# Altium schematic sheet Amplifier.SchDoc
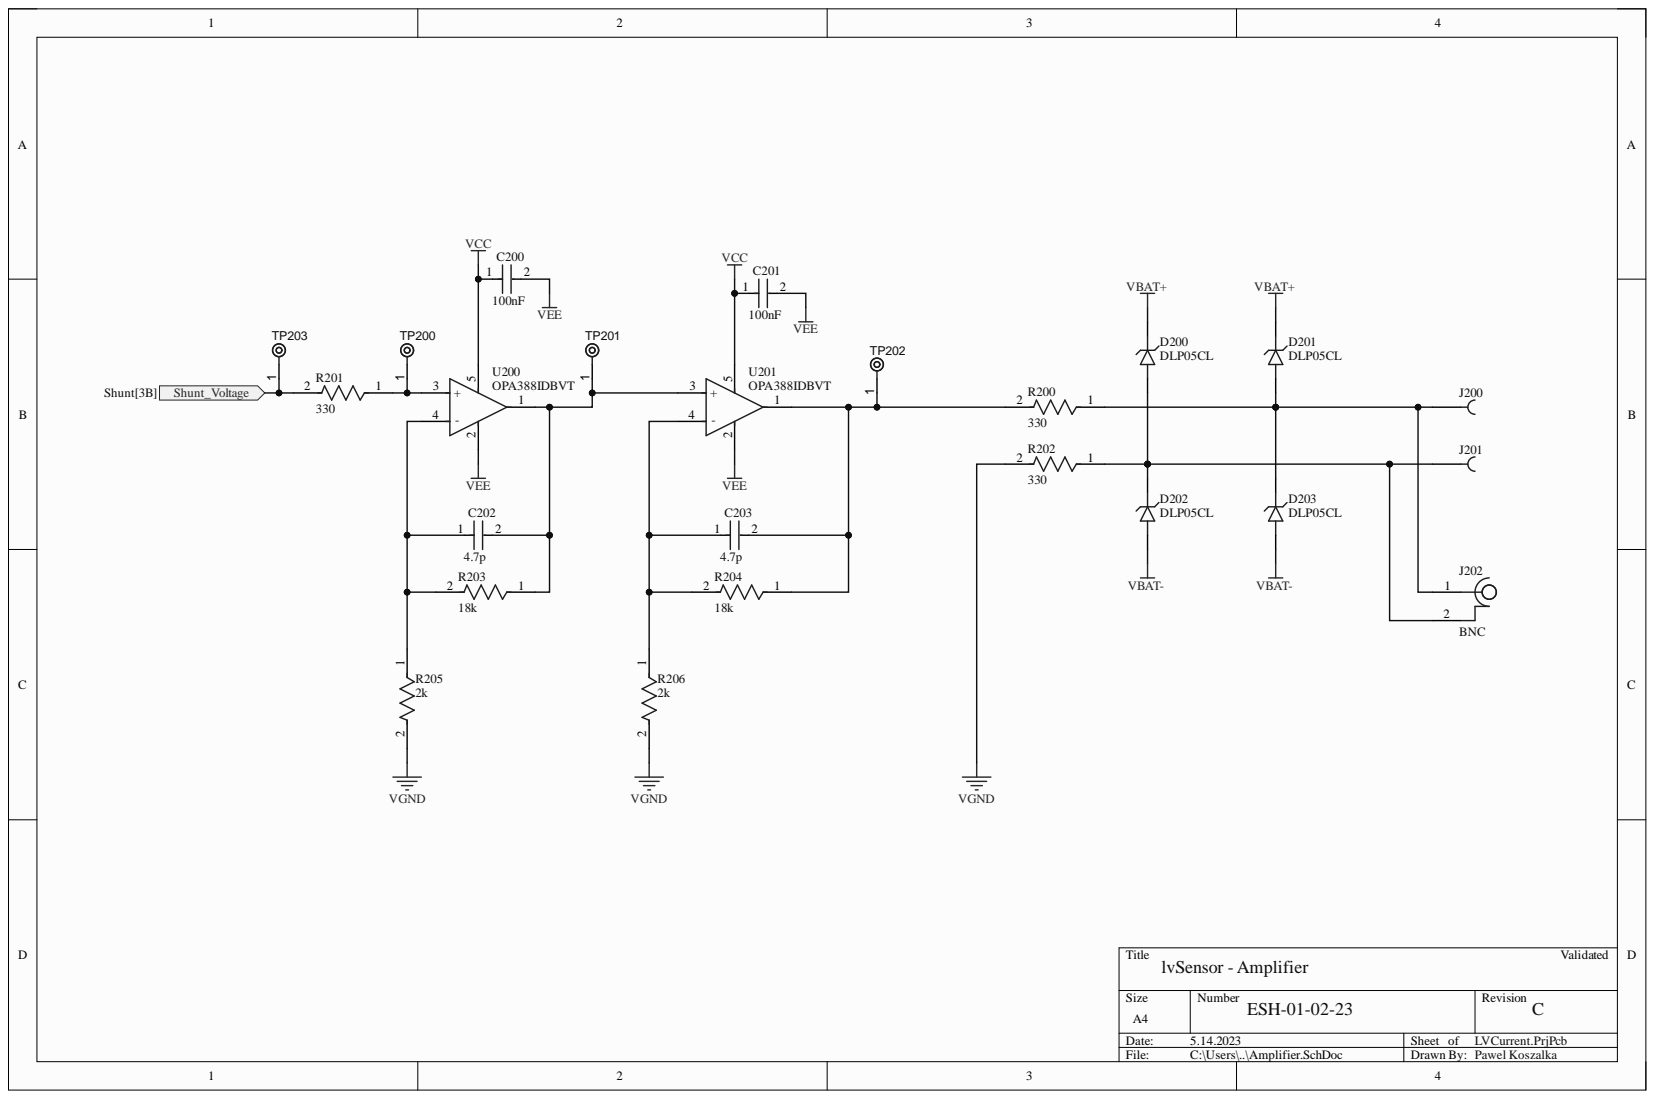
[diagram p0: Amplifier.SchDoc]

## Parts
C200  100nF  [FP-GRM21B-0_15-IPC_C]
C201  100nF  [FP-GRM21B-0_15-IPC_C]
C202  4.7p  [FP-GRM21B-0_15-IPC_C]
C203  4.7p  [FP-GRM21B-0_15-IPC_C]
D200  DLP05CL  [DLP05CL]
D201  DLP05CL  [DLP05CL]
D202  DLP05CL  [DLP05CL]
D203  DLP05CL  [DLP05CL]
J200  BananaConnector_4_Long  [BananaConnector_4mm_long]  (value not drawn)
J201  BananaConnector_4_Long  [BananaConnector_4mm_long]  (value not drawn)
J202  BNC  [BNC_Routed_Hole]
R200  330  [FP-RC1206-MFG]
R201  330  [FP-RC1206-MFG]
R202  330  [FP-RC1206-MFG]
R203  18k  [FP-RC1206-MFG]
R204  18k  [FP-RC1206-MFG]
R205  2k  [FP-RC1206-MFG]
R206  2k  [FP-RC1206-MFG]
TP200  TPAD6R  [TP06R]  (value not drawn)  (no BOM)
TP201  TPAD6R  [TP06R]  (value not drawn)  (no BOM)
TP202  TPAD6R  [TP06R]  (value not drawn)  (no BOM)
TP203  TPAD6R  [TP06R]  (value not drawn)  (no BOM)
U200  OPA388IDBVT  [FP-DBV0005A-MFG]
U201  OPA388IDBVT  [FP-DBV0005A-MFG]

## Ports
Shunt_Voltage

## Nets
VBAT+: D200.1 D201.1
VBAT-: D202.2 D203.2
VCC: C200.1 C201.1 U200.5 U201.5
VEE: C200.2 C201.2 U200.2 U201.2
VGND: R202.2 R205.2 R206.2
Shunt_Voltage: R201.2 TP203.1 ; port Shunt_Voltage
NetC202_1: C202.1 R203.2 R205.1 U200.4(-)
NetC202_2: C202.2 R203.1 TP201.1 U200.1 U201.3(+)
NetC203_1: C203.1 R204.2 R206.1 U201.4(-)
NetC203_2: C203.2 R200.2 R204.1 TP202.1 U201.1
NetD200_2: D200.2 D202.1 J201.1 J202.2 R202.1
NetD201_2: D201.2 D203.1 J200.1 J202.1 R200.1
NetR201_1: R201.1 TP200.1 U200.3(+)

## Library data of the parts
C200 C201 = CMP-2007-03541-2: CC0805KPX7R9104  0805 Capacitor; Capacitance: 10uF; Dielectric: X5R; Material: Ceramic; Tolerance: 10%; Voltage: 25V; Case Code (Imperial): 0805; Case Code (Metric): 2012; Case/Package: 0805; Component Kind: Standard; Depth: 1.25mm; Height: 1.25mm; Lead Free: Lead Free; Length: 2mm; Library Name: Altium Content Vault; Max Operating Temperature: 85°C; Min Operating Temperature: -55°C; Mount: Surface Mount; Package Quantity: 1; Packaging: Tape and Reel; Radiation Hardening: No; Termination: SMD/SMT; Thickness: 0.053inch; Voltage Rating: 25V; Voltage Rating (DC): 25V; Width: 0.049inch
C202 C203 = CMP-2007-03541-2: Chip Multilayer Ceramic Capacitors for General Purpose, 0805, 10uF, X5R, 15%, 10%, 25V; Capacitance: 10uF; Case Code (Imperial): 0805; Case Code (Metric): 2012; Case/Package: 0805; Component Kind: Standard; Depth: 1.25mm; Dielectric: X5R; Height: 1.25mm; Lead Free: Lead Free; Length: 2mm; Library Name: Altium Content Vault; Material: Ceramic; Max Operating Temperature: 85°C; Min Operating Temperature: -55°C; Mount: Surface Mount; Package Quantity: 1; Packaging: Tape and Reel; Radiation Hardening: No; Termination: SMD/SMT; Thickness: 0.053inch; Tolerance: 10%; Voltage: 25V; Voltage Rating: 25V; Voltage Rating (DC): 25V; Width: 0.049inch
R200-R202 = CMP-2100-03681-2: WR12X3300FTL  1206 Resistors; Manufacturer: YAGEO; Manufacturer Part Number: RC1206JR-070RL; Power Rating: 0.25W; Resistance: 0Ω; Temperature Coefficient: 300ppm/°C; Tolerance: 5%; Value: 0Ω; Voltage Rating: 200V; Automotive: No; Category: Res; Component Kind: Standard; ComponentLink2Description: Datasheet; ComponentLink2URL: https://www.yageo.com/upload/media/product/productsearch/datasheet/rchip/PYu-RC_Group_51_RoHS_L_10.pdf; Composition: Thick Film; Device Class L1: Passive Components; Device Class L2: Resistors; Device Class L3: Chip SMD Resistors; Digikey Description: RES SMD 0 OHM JUMPER 1/4W 1206; Footprint Url: https://www.yageo.com/upload/website/yageo_PYu-R_Mount_10_19050818_343.pdf; Height: 0.65mm; Ipc Land Pattern Name: RESC310160X55; Lead Free: Yes; Library Name: Altium Content Vault; Mouser Description: Resistor: thick film; SMD; 1206; 0O; 0.25W; ±5%; -55÷155°C; Octopart: https://octopart.com/rc1206jr-070rl-yageo-40025601; Package: RC1206; RoHS: TRUE; Series: RC; Temperature: -55°C to +155°C; Temperature Range High: +155°C; Temperature Range Low: -55°C
R203 R204 = CMP-2100-03681-2: AR1206-18K-0.1%  1206 Resistor; Manufacturer: YAGEO; Manufacturer Part Number: RC1206JR-070RL; Power Rating: 0.25W; Resistance: 0Ω; Temperature Coefficient: 300ppm/°C; Tolerance: 5%; Value: 0Ω; Voltage Rating: 200V; Automotive: No; Category: Res; Component Kind: Standard; ComponentLink2Description: Datasheet; ComponentLink2URL: https://www.yageo.com/upload/media/product/productsearch/datasheet/rchip/PYu-RC_Group_51_RoHS_L_10.pdf; Composition: Thick Film; Device Class L1: Passive Components; Device Class L2: Resistors; Device Class L3: Chip SMD Resistors; Digikey Description: RES SMD 0 OHM JUMPER 1/4W 1206; Footprint Url: https://www.yageo.com/upload/website/yageo_PYu-R_Mount_10_19050818_343.pdf; Height: 0.65mm; Ipc Land Pattern Name: RESC310160X55; Lead Free: Yes; Library Name: Altium Content Vault; Mouser Description: Resistor: thick film; SMD; 1206; 0O; 0.25W; ±5%; -55÷155°C; Octopart: https://octopart.com/rc1206jr-070rl-yageo-40025601; Package: RC1206; RoHS: TRUE; Series: RC; Temperature: -55°C to +155°C; Temperature Range High: +155°C; Temperature Range Low: -55°C
R205 R206 = CMP-2100-03681-2: AR1206-2K-0.1%  1206 Resistor; Manufacturer: YAGEO; Manufacturer Part Number: RC1206JR-070RL; Power Rating: 0.25W; Resistance: 0Ω; Temperature Coefficient: 300ppm/°C; Tolerance: 5%; Value: 0Ω; Voltage Rating: 200V; Automotive: No; Category: Res; Component Kind: Standard; ComponentLink2Description: Datasheet; ComponentLink2URL: https://www.yageo.com/upload/media/product/productsearch/datasheet/rchip/PYu-RC_Group_51_RoHS_L_10.pdf; Composition: Thick Film; Device Class L1: Passive Components; Device Class L2: Resistors; Device Class L3: Chip SMD Resistors; Digikey Description: RES SMD 0 OHM JUMPER 1/4W 1206; Footprint Url: https://www.yageo.com/upload/website/yageo_PYu-R_Mount_10_19050818_343.pdf; Height: 0.65mm; Ipc Land Pattern Name: RESC310160X55; Lead Free: Yes; Library Name: Altium Content Vault; Mouser Description: Resistor: thick film; SMD; 1206; 0O; 0.25W; ±5%; -55÷155°C; Octopart: https://octopart.com/rc1206jr-070rl-yageo-40025601; Package: RC1206; RoHS: TRUE; Series: RC; Temperature: -55°C to +155°C; Temperature Range High: +155°C; Temperature Range Low: -55°C
TP200-TP203 = CMP-00028-00002-2: 0.6mm round; Library Name: LabDevices; Pins: 1
U200 U201 = CMP-04895-000311-1: IC OPAMP ZER-DRIFT 1CIRC SOT23-5; Number of Channels: 1; Component Kind: Standard; Library Name: Altium Content Vault; Max Operating Temperature: 125°C; Min Operating Temperature: -40°C; RoHS Compliant: Yes
D200-D203 = DLP05CL: Component Kind: Standard; Library Name: DLP05CL.SchLib
J200 J201 = BananaConnector_4_Long: Component Kind: Standard; Library Name: Connectors_diy.SchLib
J202 = BNC: Component Kind: Standard; Library Name: Connectors_diy.SchLib
